# Altium schematic sheet EthernetSwitch.SchDoc
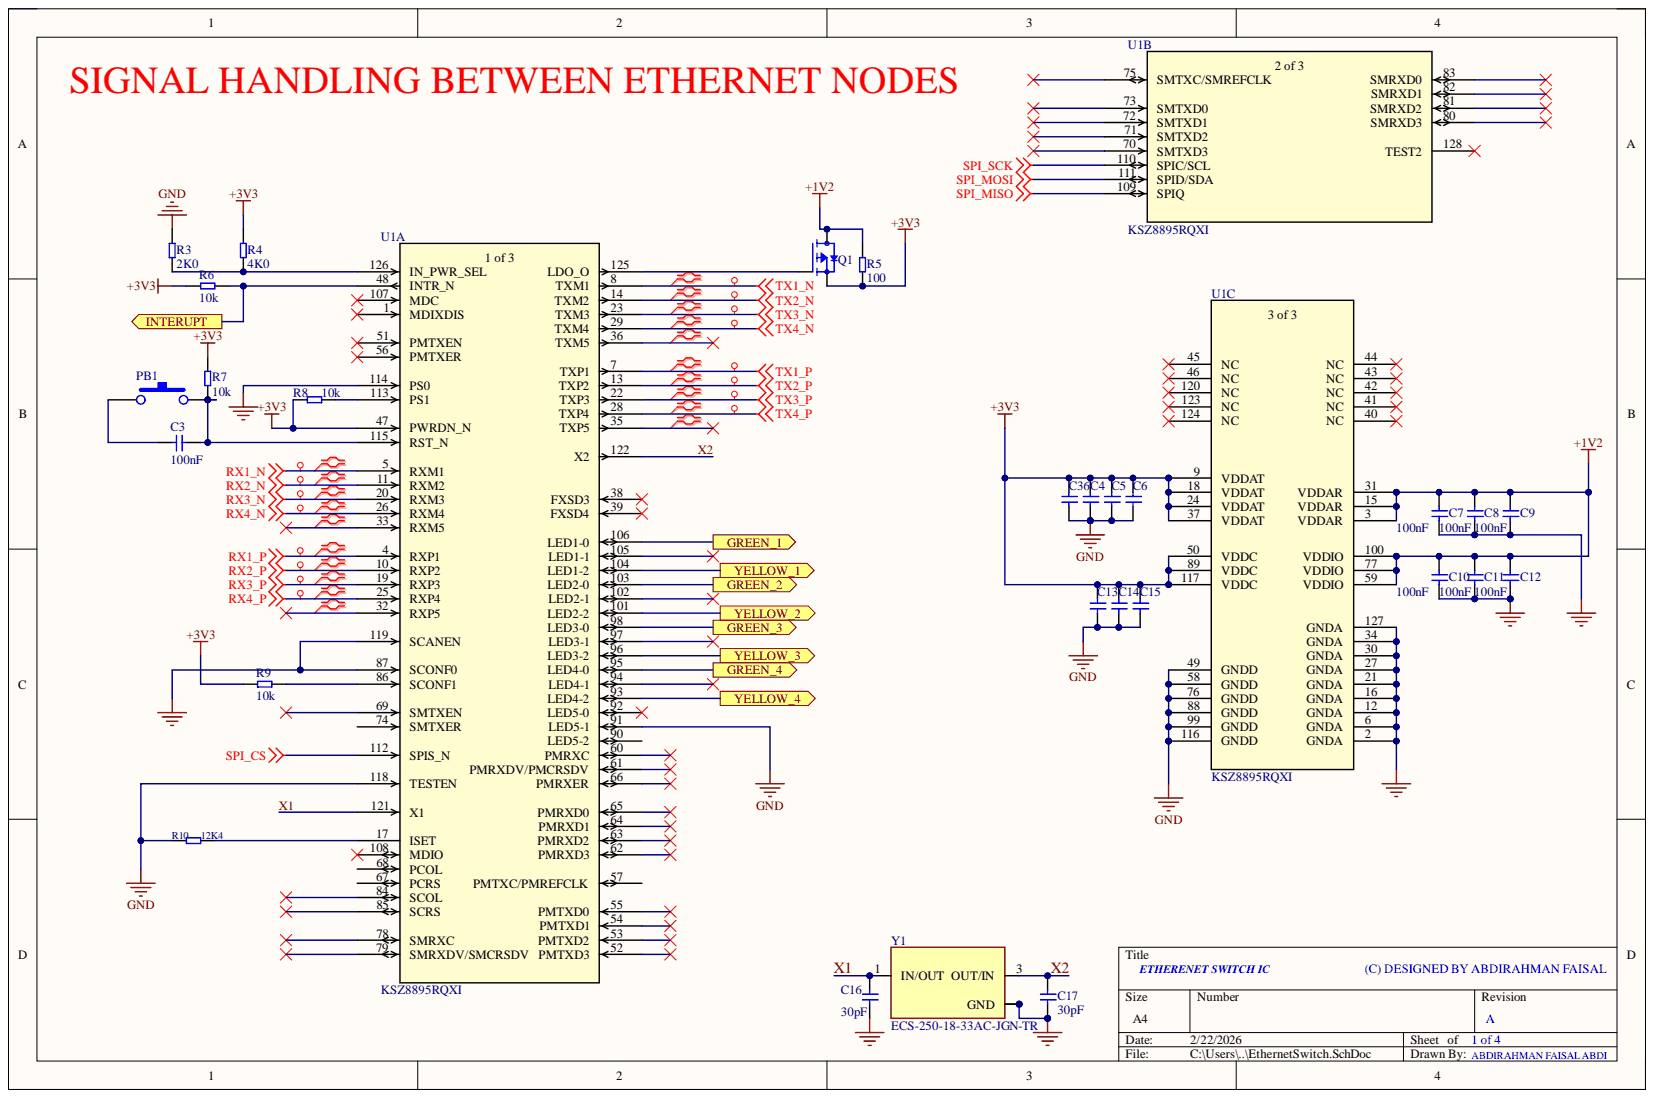
[diagram p0: EthernetSwitch.SchDoc]

## Parts
C3  100nF  [C0603]
C4  100nF  [C0603]  (value not drawn)
C5  100nF  [C0603]  (value not drawn)
C6  100nF  [C0603]  (value not drawn)
C7  100nF  [C0603]
C8  100nF  [C0603]
C9  100nF  [C0603]
C10  100nF  [C0603]
C11  100nF  [C0603]
C12  100nF  [C0603]
C13  100nF  [C0603]  (value not drawn)
C14  100nF  [C0603]  (value not drawn)
C15  100nF  [C0603]  (value not drawn)
C16  30pF  [C0603]
C17  30pF  [C0603]
C36  100nF  [C0603]  (value not drawn)
PB1  PUSH_BUTTON  [Push Button SPST]  (value not drawn)
Q1  MOSFET P-CH  [SOT91P240X110-3N_DMG2305UX-7]  (value not drawn)
R3  2K0  [R0603]
R4  4K0  [R0603]
R5  100  [R0603]
R6  10k  [R0603]
R7  10k  [R0603]
R8  10k  [R0603]
R9  10k  [R0603]
R10  12K4  [R0603]
U1  KSZ8895RQXI  [PQFP-128_MQL_MCH]  (parts A,B,C)
Y1  ECS-250-18-33AC-JGN-TR  [XTAL_ECS-250-18-33-JGN-TR]

## Ports
GREEN_1, GREEN_2, GREEN_3, GREEN_4, INTERUPT, YELLOW_1, YELLOW_2, YELLOW_3, YELLOW_4

## Nets
+1V2: C7.2 C8.2 C9.2 C10.2 C11.2 C12.2 Q1.3 R5.1 U1.3(VDDAR) U1.15(VDDAR) U1.31(VDDAR) U1.59(VDDIO) U1.77(VDDIO) U1.100(VDDIO)
+3V3: C4.2 C5.2 C6.2 C13.2 C14.2 C15.2 C36.2 Q1.2 R4.2 R5.2 R6.2 R7.2 R8.1 R9.2 U1.9(VDDAT) U1.18(VDDAT) U1.24(VDDAT) U1.37(VDDAT) U1.47(PWRDN_N) U1.50(VDDC) U1.89(VDDC) U1.117(VDDC)
GND: C4.1 C5.1 C6.1 C7.1 C8.1 C9.1 C10.1 C11.1 C12.1 C13.1 C14.1 C15.1 C16.1 C17.1 C36.1 R3.2 R10.1 U1.2(GNDA) U1.6(GNDA) U1.12(GNDA) U1.16(GNDA) U1.21(GNDA) U1.27(GNDA) U1.30(GNDA) U1.34(GNDA) U1.49(GNDD) U1.58(GNDD) U1.76(GNDD) U1.87(SCONF0) U1.88(GNDD) U1.91(LED5-1) U1.99(GNDD) U1.114(PS0) U1.116(GNDD) U1.118(TESTEN) U1.119(SCANEN) U1.127(GNDA) Y1.2(GND) Y1.4
RX1_N: U1.5(RXM1)
RX1_P: U1.4(RXP1)
RX2_N: U1.11(RXM2)
RX2_P: U1.10(RXP2)
RX3_N: U1.20(RXM3)
RX3_P: U1.19(RXP3)
RX4_N: U1.26(RXM4)
RX4_P: U1.25(RXP4)
SPI_CS: U1.112(SPIS_N)
SPI_MISO: U1.109(SPIQ)
SPI_MOSI: U1.111(SPID/SDA)
SPI_SCK: U1.110(SPIC/SCL)
TX1_N: U1.8(TXM1)
TX1_P: U1.7(TXP1)
TX2_N: U1.14(TXM2)
TX2_P: U1.13(TXP2)
TX3_N: U1.23(TXM3)
TX3_P: U1.22(TXP3)
TX4_N: U1.29(TXM4)
TX4_P: U1.28(TXP4)
GREEN_1: U1.106(LED1-0) ; port GREEN_1
GREEN_2: U1.103(LED2-0) ; port GREEN_2
GREEN_3: U1.98(LED3-0) ; port GREEN_3
GREEN_4: U1.95(LED4-0) ; port GREEN_4
INTERUPT: R6.1 U1.48(INTR_N) ; port INTERUPT
X1: C16.2 U1.121(X1) Y1.1(IN/OUT)
X2: C17.2 U1.122(X2) Y1.3(OUT/IN)
YELLOW_1: U1.104(LED1-2) ; port YELLOW_1
YELLOW_2: U1.101(LED2-2) ; port YELLOW_2
YELLOW_3: U1.96(LED3-2) ; port YELLOW_3
YELLOW_4: U1.93(LED4-2) ; port YELLOW_4
NetC3_1: C3.1 PB1.1
NetC3_2: C3.2 PB1.2 R7.1 U1.115(RST_N)
NetQ1_1: Q1.1 U1.125(LDO_O)
NetR3_1: R3.1 R4.1 U1.126(IN_PWR_SEL)
NetR8_2: R8.2 U1.113(PS1)
NetR9_1: R9.1 U1.86(SCONF1)
NetR10_2: R10.2 U1.17(ISET)

Not connected: U1 1(MDIXDIS) 32(RXP5) 33(RXM5) 35(TXP5) 36(TXM5) 38(FXSD3) 39(FXSD4) 40(NC) 41(NC) 42(NC) 43(NC) 44(NC) 45(NC) 46(NC) 51(PMTXEN) 52(PMTXD3) 53(PMTXD2) 54(PMTXD1) 55(PMTXD0) 56(PMTXER) 57(PMTXC/PMREFCLK) 60(PMRXC) 61(PMRXDV/PMCRSDV) 62(PMRXD3) 63(PMRXD2) 64(PMRXD1) 65(PMRXD0) 66(PMRXER) 67(PCRS) 68(PCOL) 69(SMTXEN) 70(SMTXD3) 71(SMTXD2) 72(SMTXD1) 73(SMTXD0) 74(SMTXER) 75(SMTXC/SMREFCLK) 78(SMRXC) 79(SMRXDV/SMCRSDV) 80(SMRXD3) 81(SMRXD2) 82(SMRXD1) 83(SMRXD0) 84(SCOL) 85(SCRS) 90(LED5-2) 92(LED5-0) 94(LED4-1) 97(LED3-1) 102(LED2-1) 105(LED1-1) 107(MDC) 108(MDIO) 120(NC) 123(NC) 124(NC) 128(TEST2)

## Library data of the parts
C3-C15 C36 = CGA3E3X8R1H104K080AD: CAP CER 0.1UF 50V X8R 0603 EPOXY; Manufacturer: TDK; Category: Ceramic Capacitors; Component Kind: Standard; ComponentLink1Description: https://datasheet.ciiva.com/24219/cga3e3x8r1h104k080ad-270768-24219691.pdf?src-supplier=Mouser; ComponentLink1URL: https://datasheet.ciiva.com/24219/cga3e3x8r1h104k080ad-270768-24219691.pdf?src-supplier=Mouser; LCSC part number: C2171928; Manufacturer Part Number: CGA3E3X8R1H104K080AD; Pricing 1: 1=0.22, 10=0.129, 50=0.0922, 100=0.0693, 500=0.06232, 1000=0.05656 (USD); RoHS: Yes; Stock 1: 30979; Supplier 1: Digikey; Library Name: arfaisal.IntLib
  C13: Simulation: Model Name
C16 C17 = AC0603JRNPO9BN300: CAP CER 30PF 50V C0G/NPO 0603; Manufacturer: Yageo; Category: Ceramic Capacitors; Component Kind: Standard; LCSC part number: C2191217; Manufacturer Part Number: AC0603JRNPO9BN300; Pricing 1: 1=0.11, 10=0.061, 50=0.0424, 100=0.035, 500=0.02706, 1000=0.02316 (USD); RoHS: No; Stock 1: 2686; Supplier 1: Digikey; Library Name: arfaisal.IntLib
PB1 = PB: Component Kind: Standard; Library Name: arfaisal.IntLib
Q1 = DMG2305UX-7: MOSFET P-CH 20V 4.2A SOT23; Manufacturer: Diodes Inc.; Drain to Source Breakdown Voltage: -20V; Drain to Source Resistance: 52mΩ; Element Configuration: Single; Fall Time: 34.7ns; Gate to Source Voltage (Vgs): 8V; Input Capacitance: 808pF; Max Junction Temperature (Tj): 150°C; Max Power Dissipation: 1.4W; Number of Channels: 1; Rise Time: 13.7ns; Threshold Voltage: -900mV; Turn-Off Delay Time: 79.3ns; Turn-On Delay Time: 10.8ns; Case/Package: SOT-23; Category: MOSFETs; China RoHS: Compliant; Component Kind: Standard; ComponentLink1Description: https://datasheet.octopart.com:443/DMG2305UX-7-Diodes-Inc.-datasheet-179056679.pdf?src-supplier=Newark; ComponentLink1URL: https://datasheet.octopart.com:443/DMG2305UX-7-Diodes-Inc.-datasheet-179056679.pdf?src-supplier=Newark; ComponentLink2Description: https://datasheet.octopart.com:443/DMG2305UX-7-Diodes-Inc.-datasheet-78715962.pdf?src-supplier=Newark; ComponentLink2URL: https://datasheet.octopart.com:443/DMG2305UX-7-Diodes-Inc.-datasheet-78715962.pdf?src-supplier=Newark; ComponentLink3Description: https://datasheet.octopart.com:443/DMG2305UX-7-Diodes-Inc.-datasheet-46751326.pdf?src-supplier=Upverter; ComponentLink3URL: https://datasheet.octopart.com:443/DMG2305UX-7-Diodes-Inc.-datasheet-46751326.pdf?src-supplier=Upverter; ComponentLink4Description: https://datasheet.octopart.com:443/DMG2305UX-7-Diodes-Inc.-datasheet-134530568.pdf?src-supplier=Future+Electronics; ComponentLink4URL: https://datasheet.octopart.com:443/DMG2305UX-7-Diodes-Inc.-datasheet-134530568.pdf?src-supplier=Future+Electronics; ComponentLink5Description: https://datasheet.octopart.com:443/DMG2305UX-7-Diodes-Inc.-datasheet-17558831.pdf?src-supplier=Future+Electronics; ComponentLink5URL: https://datasheet.octopart.com:443/DMG2305UX-7-Diodes-Inc.-datasheet-17558831.pdf?src-supplier=Future+Electronics; ComponentLink6Description: https://sigma.octopart.com:443/138706058/technical_drawing/Diodes-Inc.-DMG2305UX-7.pdf?src-supplier=Diodes+Inc+SCT; ComponentLink6URL: https://sigma.octopart.com:443/138706058/technical_drawing/Diodes-Inc.-DMG2305UX-7.pdf?src-supplier=Diodes+Inc+SCT; ComponentLink7Description: https://datasheet.octopart.com:443/DMG2305UX-7-Diodes-Inc.-datasheet-86647169.pdf?src-supplier=TME; ComponentLink7URL: https://datasheet.octopart.com:443/DMG2305UX-7-Diodes-Inc.-datasheet-86647169.pdf?src-supplier=TME; ComponentLink8Description: http://sigma.octopart.com:80/15540767/rohs_statement/Diodes-Inc.-DMG2305UX-7.pdf?src-supplier=Compliance+Statements; ComponentLink8URL: http://sigma.octopart.com:80/15540767/rohs_statement/Diodes-Inc.-DMG2305UX-7.pdf?src-supplier=Compliance+Statements; Contact Plating: Tin; Continuous Drain Current (ID): 4.2A; Drain to Source Voltage (Vdss): -20V; Height: 1.1mm; Lead Free: Lead Free; Length: 3mm; Lifecycle Status: Production; Manufacturer Part Number: DMG2305UX-7; Max Operating Temperature: 150°C; Min Breakdown Voltage: 20V; Min Operating Temperature: -55°C; Mount: Surface Mount; Number of Elements: 1; Number of Pins: 3; Number of Terminals: 3; Packaging: Tape and Reel; Power Dissipation: 1.4W; Pricing 1: 1=0.17, 10=0.113, 100=0.0809, 500=0.0777, 1000=0.07455 (USD); Radiation Hardening: No; Rds On Max: 52mΩ; REACH SVHC: Yes; Resistance: 52MΩ; RoHS: Compliant; Schedule B: 8541290080, 8541290080|8541290080|8541290080|8541290080|8541290080; Stock 1: 37730; Supplier 1: DigiKey; Weight: 7.994566mg; Width: 1.4mm; Library Name: arfaisal.IntLib
R3 = CRCW06032K00FKEAC: RES 2K OHM 1% 1/10W 0603; Manufacturer: Vishay; Power Dissipation: 100mW; Resistance: 2kΩ; Temperature Coefficient: 100ppm/°C; Voltage Rating: 75V; Case/Package: 0603; Category: Chip SMD Resistors; China RoHS: Non-Compliant; Component Kind: Standard; ComponentLink1Description: https://datasheet.octopart.com:443/CRCW06032K00FKEAC-Vishay-datasheet-102570912.pdf?src-supplier=Upverter; ComponentLink1URL: https://datasheet.octopart.com:443/CRCW06032K00FKEAC-Vishay-datasheet-102570912.pdf?src-supplier=Upverter; ComponentLink2Description: https://datasheet.octopart.com:443/CRCW06032K00FKEAC-Vishay-datasheet-175423585.pdf?src-supplier=Newark; ComponentLink2URL: https://datasheet.octopart.com:443/CRCW06032K00FKEAC-Vishay-datasheet-175423585.pdf?src-supplier=Newark; ComponentLink3Description: https://datasheet.octopart.com:443/CRCW06032K00FKEAC-Vishay-datasheet-100063072.pdf?src-supplier=Future+Electronics; ComponentLink3URL: https://datasheet.octopart.com:443/CRCW06032K00FKEAC-Vishay-datasheet-100063072.pdf?src-supplier=Future+Electronics; ComponentLink4Description: http://sigma.octopart.com:80/rohs_statement/Vishay-company-15.pdf?src-supplier=Compliance+Statements; ComponentLink4URL: http://sigma.octopart.com:80/rohs_statement/Vishay-company-15.pdf?src-supplier=Compliance+Statements; Composition: Thick Film; Contact Plating: Tin; Height: 450µm; Lead Free: Lead Free; Length: 1.55mm; Lifecycle Status: Production; Manufacturer Part Number: CRCW06032K00FKEAC; Max Operating Temperature: 155°C; Min Operating Temperature: -55°C; Mount: Surface Mount; Number of Terminals: 2; Power Rating: 100mW; Pricing 1: 1=0.1, 10=0.029, 25=0.0216, 50=0.0176, 100=0.0143, 250=0.0112, 500=0.00942, 1000=0.00803 (USD); REACH SVHC: Yes; RoHS: Compliant; Schedule B: 8533210045, 8533210045|8533210045, 8533210045|8533210045|8533210045, 8533210045|8533210045|8533210045|8533210045; Stock 1: 150170; Supplier 1: DigiKey; Termination: Wraparound; Tolerance: 1%; Width: 800µm; Working Voltage: 75V; Library Name: arfaisal.IntLib
R4 = RC0603FR-074K02L: RES 4.02K OHM 1% 1/10W 0603; Manufacturer: Yageo Group; Max Power Dissipation: 100mW; Resistance: 4.02kΩ; Temperature Coefficient: 100ppm/°C; Voltage Rating: 75V; Voltage Rating (DC): 50V; Case Code (Imperial): 0603; Case/Package: 0603; Category: Chip SMD Resistors; China RoHS: Non-Compliant; Component Kind: Standard; ComponentLink1Description: https://datasheet.octopart.com:443/RC0603FR-074K02L-Yageo-datasheet-175887523.pdf?src-supplier=IHS; ComponentLink1URL: https://datasheet.octopart.com:443/RC0603FR-074K02L-Yageo-datasheet-175887523.pdf?src-supplier=IHS; ComponentLink2Description: https://datasheet.octopart.com:443/RC0603FR-074K02L-Yageo-datasheet-103092701.pdf?src-supplier=Upverter; ComponentLink2URL: https://datasheet.octopart.com:443/RC0603FR-074K02L-Yageo-datasheet-103092701.pdf?src-supplier=Upverter; ComponentLink3Description: https://sigma.octopart.com:443/138820526/technical_drawing/Yageo-RC0603FR-074K02L.pdf?src-supplier=Upverter; ComponentLink3URL: https://sigma.octopart.com:443/138820526/technical_drawing/Yageo-RC0603FR-074K02L.pdf?src-supplier=Upverter; ComponentLink4Description: https://datasheet.octopart.com:443/RC0603FR-074K02L-Yageo-datasheet-8328172.pdf?src-supplier=Burklin+Elektronik; ComponentLink4URL: https://datasheet.octopart.com:443/RC0603FR-074K02L-Yageo-datasheet-8328172.pdf?src-supplier=Burklin+Elektronik; ComponentLink5Description: https://datasheet.octopart.com:443/RC0603FR-074K02L-Yageo-datasheet-164759695.pdf?src-supplier=Future+Electronics; ComponentLink5URL: https://datasheet.octopart.com:443/RC0603FR-074K02L-Yageo-datasheet-164759695.pdf?src-supplier=Future+Electronics; ComponentLink6Description: https://datasheet.octopart.com:443/RC0603FR-074K02L-Yageo-datasheet-137700087.pdf?src-supplier=Future+Electronics; ComponentLink6URL: https://datasheet.octopart.com:443/RC0603FR-074K02L-Yageo-datasheet-137700087.pdf?src-supplier=Future+Electronics; ComponentLink7Description: https://datasheet.octopart.com:443/RC0603FR-074K02L-Yageo-datasheet-17842380.pdf?src-supplier=Future+Electronics; ComponentLink7URL: https://datasheet.octopart.com:443/RC0603FR-074K02L-Yageo-datasheet-17842380.pdf?src-supplier=Future+Electronics; ComponentLink8Description: https://datasheet.octopart.com:443/RC0603FR-074K02L-Yageo-datasheet-15599030.pdf?src-supplier=Future+Electronics; ComponentLink8URL: https://datasheet.octopart.com:443/RC0603FR-074K02L-Yageo-datasheet-15599030.pdf?src-supplier=Future+Electronics; ComponentLink9Description: https://datasheet.octopart.com:443/RC0603FR-074K02L-Yageo-datasheet-12596169.pdf?src-supplier=Future+Electronics; ComponentLink9URL: https://datasheet.octopart.com:443/RC0603FR-074K02L-Yageo-datasheet-12596169.pdf?src-supplier=Future+Electronics; ComponentLink10Description: https://datasheet.octopart.com:443/RC0603FR-074K02L-Yageo-datasheet-148775077.pdf?src-supplier=TME; ComponentLink10URL: https://datasheet.octopart.com:443/RC0603FR-074K02L-Yageo-datasheet-148775077.pdf?src-supplier=TME; ComponentLink11Description: https://datasheet.octopart.com/RC0603FR-074K02L-Yageo-datasheet-73766026.pdf; ComponentLink11URL: https://datasheet.octopart.com/RC0603FR-074K02L-Yageo-datasheet-73766026.pdf; ComponentLink12Description: https://datasheet.octopart.com:443/RC0603FR-074K02L-Yageo-datasheet-118562.pdf?src-supplier=DigiKey; ComponentLink12URL: https://datasheet.octopart.com:443/RC0603FR-074K02L-Yageo-datasheet-118562.pdf?src-supplier=DigiKey; ComponentLink13Description: https://datasheet.octopart.com:443/RC0603FR-074K02L-Yageo-datasheet-62067350.pdf?src-supplier=Newark; ComponentLink13URL: https://datasheet.octopart.com:443/RC0603FR-074K02L-Yageo-datasheet-62067350.pdf?src-supplier=Newark; ComponentLink14Description: http://sigma.octopart.com:80/rohs_statement/Yageo-company-2.pdf?src-supplier=Compliance+Statements; ComponentLink14URL: http://sigma.octopart.com:80/rohs_statement/Yageo-company-2.pdf?src-supplier=Compliance+Statements; ComponentLink15Description: http://sigma.octopart.com:80/conflict_mineral_statement/Yageo-company-3.pdf?src-supplier=Compliance+Statements; ComponentLink15URL: http://sigma.octopart.com:80/conflict_mineral_statement/Yageo-company-3.pdf?src-supplier=Compliance+Statements; Composition: Thick Film; Depth: 800µm; Features: Moisture Resistant; Height: 450µm; Lead Free: Lead Free; Length: 1.6002mm; Lifecycle Status: Production; Manufacturer Part Number: RC0603FR-074K02L; Max Operating Temperature: 155°C; Military Standard: Not; Min Operating Temperature: -55°C; Mount: Surface Mount; Number of Terminals: 2; Number of Terminations: 2; Power Dissipation: 100mW; Power Rating: 100mW; Pricing 1: 1=0.1, 10=0.008, 25=0.0072, 50=0.0052 (USD); REACH SVHC: Yes; RoHS: Compliant; Schedule B: 8533210045, 8533210045|8533210045, 8533210045|8533210045|8533210045, 8533210045|8533210045|8533210045|8533210045; Stock 1: 107223; Supplier 1: DigiKey; Termination: SMD/SMT; Tolerance: 1%; Width: 800µm; Working Voltage: 75V; Library Name: arfaisal.IntLib
R5 = AC0603FR-13100RL: SMD Chip Resistor, 100 Ohm, ï¿½ 1%, 100 mW, 0603 [1608 Metric], Thick Film, General Purpose; Manufacturer: Yageo; Power Rating: 100mW; Temperature Coefficient: 100ppm/°C; Voltage Rating: 75V; Category: Chip SMD Resistors; Component Kind: Standard; ComponentLink1Description: https://datasheet.octopart.com/AC0603FR-13100RL-Yageo-datasheet-151884373.pdf?src-supplier=Future+Electronics; ComponentLink1URL: https://datasheet.octopart.com/AC0603FR-13100RL-Yageo-datasheet-151884373.pdf?src-supplier=Future+Electronics; Height: 550um; LCSC part number: C1512172; Manufacturer Part Number: AC0603FR-13100RL; Max Operating Temperature: 155°C; Min Operating Temperature: -55°C; Packaging: 10Packaging; Pricing 1: 1=0.1, 10=0.011, 100=0.0092, 1000=0.00816, 2500=0.00612, 5000=0.0051, 10000=0.00408 (USD); RoHS: Yes; Stock 1: 86229; Supplier 1: Digikey; Library Name: arfaisal.IntLib
R6-R9 = RT0603FRE0710KL: Fixed Resistor, Thin Film, 0.1W, 10000ohm, 75V, 1% +/-Tol, 50ppm/Cel, Surface Mount, 0603; Manufacturer: Yageo; Max Power Dissipation: 100mW; Resistance: 10kR; Temperature Coefficient: 50ppm/°C; Case Code (Imperial): 0603; Case Code (Metric): 1608; Case/Package: 0603; Category: Chip SMD Resistors; Component Kind: Standard; ComponentLink1Description: https://datasheet.ciiva.com/12694/pyu-rt-1-to-0-05-rohs-l-4-12694910.pdf?src-supplier=Mouser; ComponentLink1URL: https://datasheet.ciiva.com/12694/pyu-rt-1-to-0-05-rohs-l-4-12694910.pdf?src-supplier=Mouser; ComponentLink2Description: https://datasheet.ciiva.com/16745/pyu-rt-1-to-0-05-rohs-l-4-16745739.pdf?src-supplier=Future+Electronics; ComponentLink2URL: https://datasheet.ciiva.com/16745/pyu-rt-1-to-0-05-rohs-l-4-16745739.pdf?src-supplier=Future+Electronics; ComponentLink3Description: https://datasheet.ciiva.com/2559/pyu-rt-1-to-0-05-rohs-l-5-2559482.pdf?src-supplier=Digikey; ComponentLink3URL: https://datasheet.ciiva.com/2559/pyu-rt-1-to-0-05-rohs-l-5-2559482.pdf?src-supplier=Digikey; Contact Plating: Tin,Lead; Features: Moisture Resistant; Height: 0.022inch; LCSC part number: C469659; Lead Free: 5697LeadFree; Length: 0.063inch; Manufacturer Part Number: RT0603FRE0710KL; Max Operating Temperature: 125°C; Min Operating Temperature: -55°C; Number of Pins: 2; Number of Terminations: 2; Package Quantity: 5000; Packaging: Tape and Reel; Power Rating: 100mW; Pricing 1: 1=0.1, 10=0.012, 25=0.0116, 50=0.0112, 100=0.0107, 250=0.0102 (USD); Reach SVHC Compliant: Unknown; Resistance Tolerance: 1%; Resistor Type: Thin Film; RoHS: Yes; RoHS Compliant: Yes; Series: RT; Size Code: 0603; Stock 1: 237229; Supplier 1: Digikey; Termination: SMD/SMT; Tolerance: 1%; Voltage Rating: 75V; Voltage Rating (DC): 75V; Width: 0.031inch; Library Name: arfaisal.IntLib
R10 = RC0603FR-0712K4L: RES 12.4K OHM 1% 1/10W 0603; Manufacturer: Yageo Group; Max Power Dissipation: 100mW; Resistance: 12.4kΩ; Temperature Coefficient: 100ppm/°C; Voltage Rating: 75V; Voltage Rating (DC): 50V; Case Code (Imperial): 0603; Case/Package: 0603; Category: Chip SMD Resistors; China RoHS: Non-Compliant; Component Kind: Standard; ComponentLink1Description: https://datasheet.octopart.com:443/RC0603FR-0712K4L-Yageo-datasheet-175887523.pdf?src-supplier=Newark; ComponentLink1URL: https://datasheet.octopart.com:443/RC0603FR-0712K4L-Yageo-datasheet-175887523.pdf?src-supplier=Newark; ComponentLink2Description: https://datasheet.octopart.com:443/RC0603FR-0712K4L-Yageo-datasheet-141265859.pdf?src-supplier=Upverter; ComponentLink2URL: https://datasheet.octopart.com:443/RC0603FR-0712K4L-Yageo-datasheet-141265859.pdf?src-supplier=Upverter; ComponentLink3Description: https://datasheet.octopart.com:443/RC0603FR-0712K4L-Yageo-datasheet-103092701.pdf?src-supplier=Upverter; ComponentLink3URL: https://datasheet.octopart.com:443/RC0603FR-0712K4L-Yageo-datasheet-103092701.pdf?src-supplier=Upverter; ComponentLink4Description: https://sigma.octopart.com:443/138820526/technical_drawing/Yageo-RC0603FR-0712K4L.pdf?src-supplier=Upverter; ComponentLink4URL: https://sigma.octopart.com:443/138820526/technical_drawing/Yageo-RC0603FR-0712K4L.pdf?src-supplier=Upverter; ComponentLink5Description: https://datasheet.octopart.com:443/RC0603FR-0712K4L-Yageo-datasheet-8328172.pdf?src-supplier=Future+Electronics; ComponentLink5URL: https://datasheet.octopart.com:443/RC0603FR-0712K4L-Yageo-datasheet-8328172.pdf?src-supplier=Future+Electronics; ComponentLink6Description: https://datasheet.octopart.com:443/RC0603FR-0712K4L-Yageo-datasheet-164759695.pdf?src-supplier=Future+Electronics; ComponentLink6URL: https://datasheet.octopart.com:443/RC0603FR-0712K4L-Yageo-datasheet-164759695.pdf?src-supplier=Future+Electronics; ComponentLink7Description: https://datasheet.octopart.com:443/RC0603FR-0712K4L-Yageo-datasheet-137700087.pdf?src-supplier=Future+Electronics; ComponentLink7URL: https://datasheet.octopart.com:443/RC0603FR-0712K4L-Yageo-datasheet-137700087.pdf?src-supplier=Future+Electronics; ComponentLink8Description: https://datasheet.octopart.com:443/RC0603FR-0712K4L-Yageo-datasheet-27584510.pdf?src-supplier=Future+Electronics; ComponentLink8URL: https://datasheet.octopart.com:443/RC0603FR-0712K4L-Yageo-datasheet-27584510.pdf?src-supplier=Future+Electronics; ComponentLink9Description: https://datasheet.octopart.com:443/RC0603FR-0712K4L-Yageo-datasheet-15599030.pdf?src-supplier=Future+Electronics; ComponentLink9URL: https://datasheet.octopart.com:443/RC0603FR-0712K4L-Yageo-datasheet-15599030.pdf?src-supplier=Future+Electronics; ComponentLink10Description: https://datasheet.octopart.com:443/RC0603FR-0712K4L-Yageo-datasheet-12596169.pdf?src-supplier=Future+Electronics; ComponentLink10URL: https://datasheet.octopart.com:443/RC0603FR-0712K4L-Yageo-datasheet-12596169.pdf?src-supplier=Future+Electronics; ComponentLink11Description: https://datasheet.octopart.com:443/RC0603FR-0712K4L-Yageo-datasheet-8324193.pdf?src-supplier=Future+Electronics; ComponentLink11URL: https://datasheet.octopart.com:443/RC0603FR-0712K4L-Yageo-datasheet-8324193.pdf?src-supplier=Future+Electronics; ComponentLink12Description: https://datasheet.octopart.com:443/RC0603FR-0712K4L-Yageo-datasheet-148775077.pdf?src-supplier=TME; ComponentLink12URL: https://datasheet.octopart.com:443/RC0603FR-0712K4L-Yageo-datasheet-148775077.pdf?src-supplier=TME; ComponentLink13Description: https://datasheet.octopart.com/RC0603FR-0712K4L-Yageo-datasheet-73766026.pdf; ComponentLink13URL: https://datasheet.octopart.com/RC0603FR-0712K4L-Yageo-datasheet-73766026.pdf; ComponentLink14Description: https://datasheet.octopart.com:443/RC0603FR-0712K4L-Yageo-datasheet-118562.pdf?src-supplier=DigiKey; ComponentLink14URL: https://datasheet.octopart.com:443/RC0603FR-0712K4L-Yageo-datasheet-118562.pdf?src-supplier=DigiKey; ComponentLink15Description: http://sigma.octopart.com:80/rohs_statement/Yageo-company-2.pdf?src-supplier=Compliance+Statements; ComponentLink15URL: http://sigma.octopart.com:80/rohs_statement/Yageo-company-2.pdf?src-supplier=Compliance+Statements; ComponentLink16Description: http://sigma.octopart.com:80/conflict_mineral_statement/Yageo-company-3.pdf?src-supplier=Compliance+Statements; ComponentLink16URL: http://sigma.octopart.com:80/conflict_mineral_statement/Yageo-company-3.pdf?src-supplier=Compliance+Statements; Composition: Thick Film; Depth: 800µm; Features: Moisture Resistant; Height: 450µm; Lead Free: Lead Free; Length: 1.6002mm; Lifecycle Status: Production; Manufacturer Part Number: RC0603FR-0712K4L; Max Operating Temperature: 155°C; Military Standard: Not; Min Operating Temperature: -55°C; Mount: Surface Mount; Number of Terminals: 2; Number of Terminations: 2; Packaging: Tape & Reel; Power Dissipation: 100mW; Power Rating: 100mW; Pricing 1: 1=0.1, 10=0.007, 25=0.0068, 50=0.0052 (USD); REACH SVHC: Yes; RoHS: Compliant; Schedule B: 8533210045, 8533210045|8533210045|8533210045|8533210045|8533210045|8533210045|8533210045|8533210045|8533210045|8533210045|8533210045|8533210045|8533210045, 8533210045|8533210045|8533210045|8533210045|8533210045|8533210045|8533210045|8533210045|8533210045|8533210045|8533210045|8533210045|8533210045|8533210045, 8533210045|8533210045|8533210045|8533210045|8533210045|8533210045|8533210045|8533210045|8533210045|8533210045|8533210045|8533210045|8533210045|8533210045|8533210045; Stock 1: 830255; Supplier 1: DigiKey; Termination: SMD/SMT; Tolerance: 1%; Width: 800µm; Working Voltage: 75V; Library Name: arfaisal.IntLib
U1 = KSZ8895RQXI_2: IC ETHERNET SWITCH RMII 128QFP; Manufacturer_Name: Microchip; Component Kind: Standard; Digi-Key_Part_Number_1: KSZ8895RQXI-ND; Manufacturer_Part_Number: KSZ8895RQXI; RefDes: RefDes; Type: Type; Copyright: Copyright (C) 2025 Ultra Librarian. All rights reserved.; Library Name: arfaisal.IntLib
Y1 = ECS-250-18-33-JGN-TR (2): CRYSTAL 25.0000MHZ 18PF SMD; Manufacturer: ECS International; ESR (Equivalent Series Resistance): 40R; Frequency: 25MHz; Frequency Stability: 30ppm; Frequency Tolerance: 20ppm; Case/Package: SMD/SMT; Category: Crystals; Component Kind: Standard; ComponentLink1Description: https://www.ecsxtal.com/store/pdf/ecx-32.pdf?src-supplier=Digikey; ComponentLink1URL: https://www.ecsxtal.com/store/pdf/ecx-32.pdf?src-supplier=Digikey; ComponentLink2Description: https://datasheet.octopart.com/ECS-250-18-33-JGN-TR-ECS-International-datasheet-140089731.pdf?src-supplier=Future+Electronics; ComponentLink2URL: https://datasheet.octopart.com/ECS-250-18-33-JGN-TR-ECS-International-datasheet-140089731.pdf?src-supplier=Future+Electronics; ComponentLink3Description: https://datasheet.octopart.com/ECS-250-18-33-JGN-TR-ECS-International-datasheet-17018741.pdf?src-supplier=Electro+Sonic; ComponentLink3URL: https://datasheet.octopart.com/ECS-250-18-33-JGN-TR-ECS-International-datasheet-17018741.pdf?src-supplier=Electro+Sonic; ComponentLink4Description: https://datasheet.octopart.com/ECS-250-18-33-JGN-TR-ECS-International-datasheet-179230830.pdf?src-supplier=Master+Electronics; ComponentLink4URL: https://datasheet.octopart.com/ECS-250-18-33-JGN-TR-ECS-International-datasheet-179230830.pdf?src-supplier=Master+Electronics; Load Capacitance: 18pF; Manufacturer Part Number: ECS-250-18-33-JGN-TR; Max Operating Temperature: 85°C; Min Operating Temperature: -40°C; Mount: Surface Mount; Packaging: Cut Tape; Pricing 1: 1=0.41, 10=0.355, 25=0.336, 50=0.3222, 100=0.3089, 250=0.29208, 500=0.28 (USD); RoHS: Yes; RoHS Compliant: Yes; Series: ECX-32; Stock 1: 39748; Supplier 1: Digikey; Library Name: arfaisal.IntLib
